# Altium schematic sheet Light Sensor Prototype.SchDoc("Light Sensor Prototype")
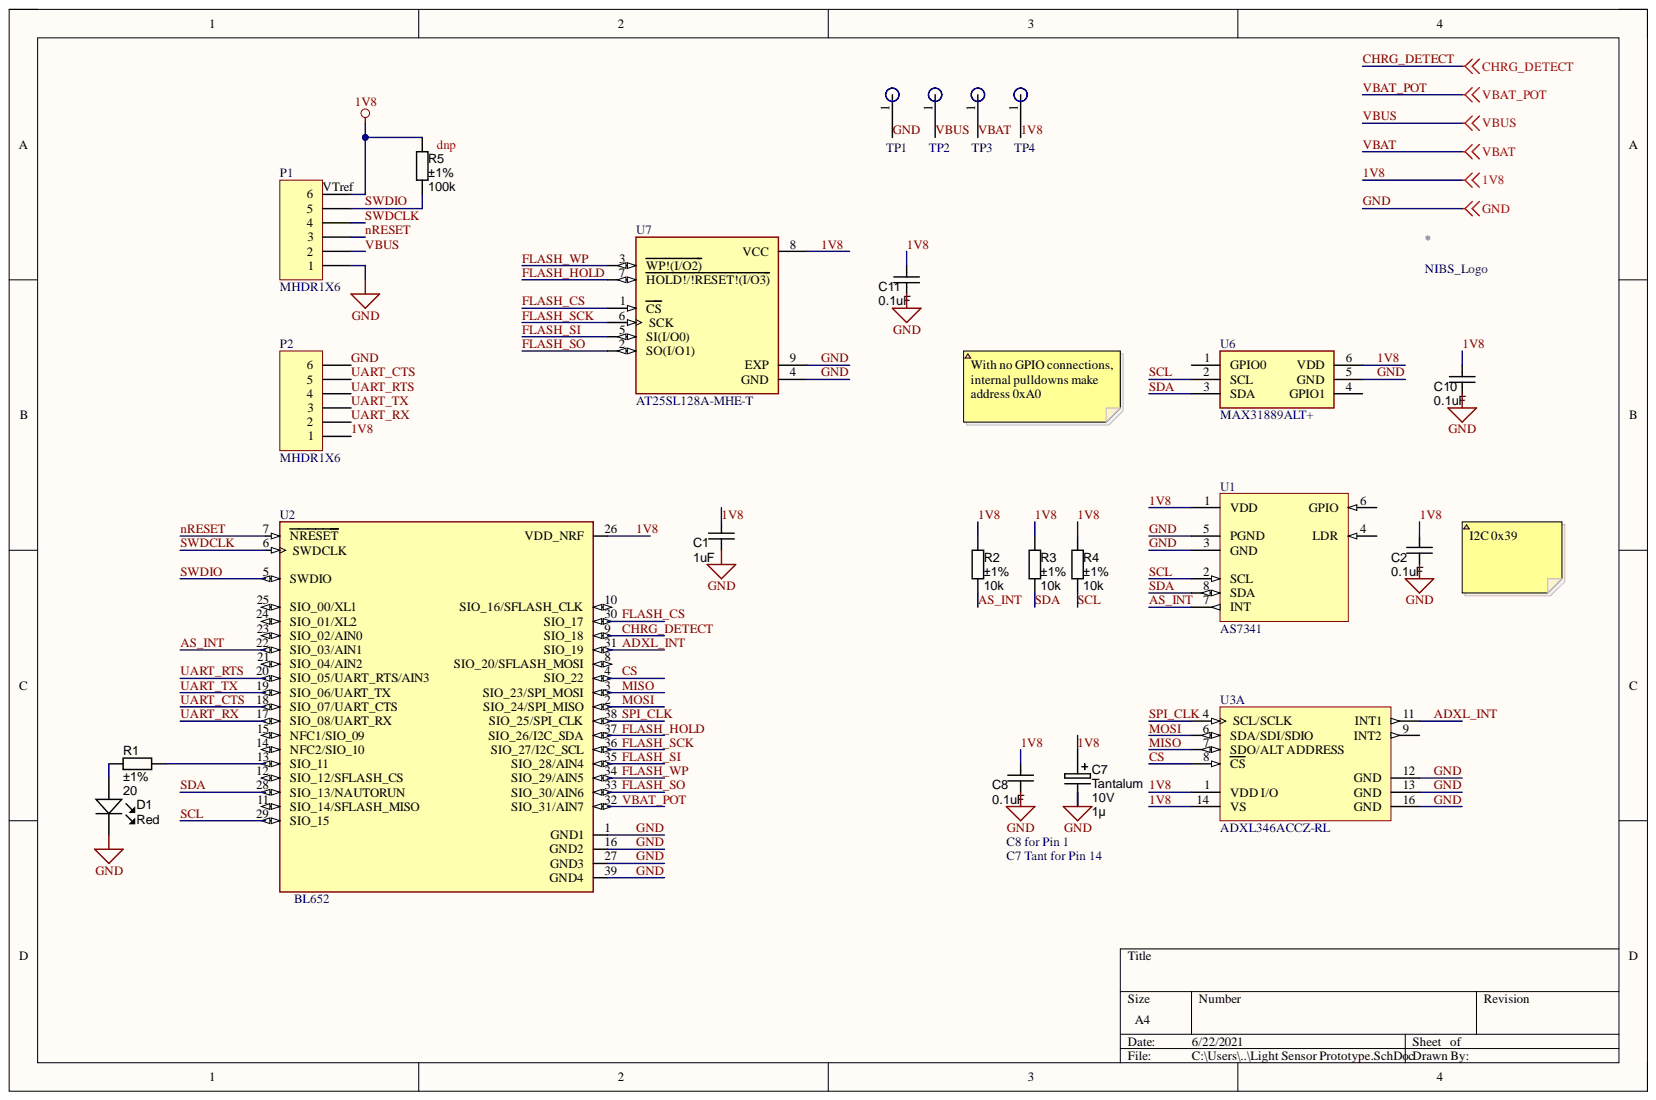
[diagram p0: Light Sensor Prototype.SchDoc]

## Parts
*  NIBS_Logo  [Logo]
C1  value 1uF  [CAPC1608X90N]  (hidden comment: approved sub OK)
C2  value 0.1uF  [CAPC1608X90N]  (hidden comment: approved sub OK)
C7  1µ  Material: Tantalum  Ratings: 10V  [CAPMP2012X150USR]
C8  value 0.1uF  [CAPC1608X90N]  (hidden comment: approved sub OK)
C10  value 0.1uF  [CAPC1608X90N]  (hidden comment: approved sub OK)
C11  value 0.1uF  [CAPC1608X90N]  (hidden comment: approved sub OK)
D1  Red  [LED-0603-RED]
P1  MHDR1X6  [MHDR1X6]
P2  MHDR1X6  [MHDR1X6]
R1  value 20  Tolerance: ±1%  [RESC1608X55N]  (hidden comment: Thick Film)
R2  value 10k  Tolerance: ±1%  [RESC1608X55N]  (hidden comment: Thick Film)
R3  value 10k  Tolerance: ±1%  [RESC1608X55N]  (hidden comment: Thick Film)
R4  value 10k  Tolerance: ±1%  [RESC1608X55N]  (hidden comment: Thick Film)
R5  value 100k  Tolerance: ±1%  [RESC1608X55N]
TP1  Test Point  [TP]  (value not drawn)
TP2  Test Point  [TP]  (value not drawn)
TP3  Test Point  [TP]  (value not drawn)
TP4  Test Point  [TP]  (value not drawn)
U1  AS7341  [OLGA8]
U2  BL652  [BL652]
U3  ADXL346ACCZ-RL  [ADI-CC-16-3_N]  (part A)
U6  MAX31889ALT+  [MAX31889ALT]
U7  AT25SL128A-MHE-T  [SON127P500X600X60-9N]

## Nets
1V8: C1.1 C2.1 C7.1 C8.1 C10.1 C11.1 P1.6 P2.1 R2.1 R3.1 R4.1 R5.2 TP4.1 U1.1(VDD) U2.26(VDD_NRF) U3.1(VDD_I/O) U3.14(VS) U6.6(VDD) U7.8(VCC)
ADXL_INT: U2.31(SIO_19) U3.11(INT1)
AS_INT: R2.2 U1.7(INT) U2.22(SIO_03/AIN1)
CHRG_DETECT: U2.9(SIO_18)
CS: U2.4(SIO_22) U3.8(CS)
FLASH_CS: U2.30(SIO_17) U7.1(CS)
FLASH_HOLD: U2.37(SIO_26/I2C_SDA) U7.7(HOLD!/!RESET!(I/O3))
FLASH_SCK: U2.36(SIO_27/I2C_SCL) U7.6(SCK)
FLASH_SI: U2.35(SIO_28/AIN4) U7.5(SI(I/O0))
FLASH_SO: U2.33(SIO_30/AIN6) U7.2(SO(I/O1))
FLASH_WP: U2.34(SIO_29/AIN5) U7.3(WP!(I/O2))
GND: C1.2 C2.2 C7.2 C8.2 C10.2 C11.2 D1.K P1.1 P2.6 TP1.1 U1.3(GND) U1.5(PGND) U2.1(GND1) U2.16(GND2) U2.27(GND3) U2.39(GND4) U3.12(GND) U3.13(GND) U3.16(GND) U6.5(GND) U7.4(GND) U7.9(EXP)
MISO: U2.3(SIO_23/SPI_MOSI) U3.7(SDO/ALT_ADDRESS)
MOSI: U2.2(SIO_24/SPI_MISO) U3.6(SDA/SDI/SDIO)
nRESET: P1.3 U2.7(NRESET)
SCL: R4.2 U1.2(SCL) U2.29(SIO_15) U6.2(SCL)
SDA: R3.2 U1.8(SDA) U2.28(SIO_13/NAUTORUN) U6.3(SDA)
SPI_CLK: U2.38(SIO_25/SPI_CLK) U3.4(SCL/SCLK)
SWDCLK: P1.4 U2.6(SWDCLK)
SWDIO: P1.5 R5.1 U2.5(SWDIO)
UART_CTS: P2.5 U2.18(SIO_07/UART_CTS)
UART_RTS: P2.4 U2.20(SIO_05/UART_RTS/AIN3)
UART_RX: P2.2 U2.17(SIO_08/UART_RX)
UART_TX: P2.3 U2.19(SIO_06/UART_TX)
VBAT: TP3.1
VBAT_POT: U2.32(SIO_31/AIN7)
VBUS: P1.2 TP2.1
NetD1_A: D1.A R1.1
NetR1_2: R1.2 U2.13(SIO_11)

Not connected: U1 4(LDR) 6(GPIO); U2 8(SIO_20/SFLASH_MOSI) 10(SIO_16/SFLASH_CLK) 11(SIO_14/SFLASH_MISO) 12(SIO_12/SFLASH_CS) 14(NFC2/SIO_10) 15(NFC1/SIO_09) 21(SIO_04/AIN2) 23(SIO_02/AIN0) 24(SIO_01/XL2) 25(SIO_00/XL1); U3 9(INT2); U6 1(GPIO0) 4(GPIO1)

## Library data of the parts
C1 C2 C8 C10 C11 = CAPC_100n_0603_X7R_50V_10%: Capacitor SMD Cer 10uF 10% 16V X5R 0603; Manufacturer: TDK; Manufacturer Part Number: C1608X5R1C106M080AB; Material: ceramic; Ratings: 16V; X5R; Component Kind: Standard; Package / Case: 0603 (1608 Metric); Supplier: Farnell; Supplier Link: https://uk.farnell.com/tdk/c1608x5r1c106m080ab/cap-10-f-16v-20-x5r-0603/dp/2525096?st=2521959; Supplier Part Number: 2525096; Tolerance: ±10%; Library Name: UEKAE.SCHLIB
C7 = CAPT_1u_0805_10V: Capacitor SMD Tantalum 1uF 20% 10V 0805; Manufacturer 1: AVX Corporation; Manufacturer Part Number 1: TAJP106M010RNJ; Manufacturer Size Code: P; ESR (Equivalent Series Resistance): 6.000 Ohm; SCDType: spec; Type: Molded; Component Kind: Standard; ComponentLink1Description: http://www.avx.com/docs/Catalogs/tajlp.pdf; ComponentLink1URL: http://www.avx.com/docs/Catalogs/tajlp.pdf; Height - Seated (Max): 0.059" (1.50mm); Operating Temperature: -55°C ~ 125°C; Package / Case: 0805 (2012 metric); Size / Dimension: 0.081" L x 0.053" W (2.05mm x 1.35mm); Supplier 1: Digi-Key; Supplier Part Number 1: 478-3281-1-ND; Tolerance: ±20%; Value: 1µ; Library Name: Libs_RRutledge.IntLib
D1 = LED_SMD_Red_0603_220mcd: Dialight 598-8E40-107F; Manufacturer 1: Kingbright Company LLC; Manufacturer Part Number 1: APT1608SURCK; Material: AlGaInP on GaAs; Ratings: 220 mcd; 630 nm; SCDType: source; Voltage - Forward (Vf) @ 10mA: 1.9V; Voltage - Forward (Vf) Typ: 1.95V; Component Kind: Standard; ComponentLink1Description: http://www.us.kingbright.com/images/catalog/SPEC/APT1608SURCK.pdf; ComponentLink1URL: http://www.us.kingbright.com/images/catalog/SPEC/APT1608SURCK.pdf; Package / Case: 0603 (1608 metric); Supplier 1: Digi-Key; Supplier Part Number 1: 754-1123-1-ND; Library Name: Libs_RRutledge.IntLib
P1 P2 = MHDR1X6: Header, 6-Pin; Component Kind: Standard; LatestRevisionDate: 17-Jul-2002; LatestRevisionNote: Re-released for DXP Platform.; Library Name: Miscellaneous Connectors.IntLib; Signal Integrity: Connector; Publisher: Altium Limited
R1 = RES_10K_0603_1%: Resistor SMD chip 10k Ohm 0.1W 1% 0603; Manufacturer 1: Yageo; Manufacturer Part Number 1: RC0603FR-0710KL; Ratings: 1/10W; SCDType: spec; Value: 20; Component Kind: Standard; Package / Case: 0603 (1608 Metric); Supplier 1: Digi-Key; Supplier Part Number 1: 311-10.0KHRCT-ND; Library Name: Libs_RRutledge.IntLib
R2-R5 = RES_10K_0603_1%: Resistor SMD chip 10k Ohm 0.1W 1% 0603; Manufacturer 1: Yageo; Manufacturer Part Number 1: RC0603FR-0710KL; Ratings: 1/10W; SCDType: spec; Component Kind: Standard; Package / Case: 0603 (1608 Metric); Supplier 1: Digi-Key; Supplier Part Number 1: 311-10.0KHRCT-ND; Library Name: Libs_RRutledge.IntLib
U1 = AS7341: AMS AS7341  11-Channel Spectral Sensor Frontend; Component Kind: Standard; Library Name: Light Sensor Prototype.SchLib
U3 = ADXL346ACCZ-RL: 3-Axis, +/-2 g / +/-4 g / +/-8 g / +/-16 g Ultralow Power Digital Accelerometer with Digital Output, 1.6 KHz BW, Industrial, 16-pin LGA, Reel; Manufacturer: Analog Devices; # of Axes: 3; -3dB Bandwidth: 1.6 KHz; Sensitivity: 256/128/64/32 LSB/g; Supply Current: 140 uA; Voltage Supply (V): 1.7 to 2.75; Component Kind: Standard; ComponentLink1Description: Manufacturer URL; ComponentLink1URL: http://www.analog.com/en/index.html; ComponentLink2Description: Datasheet; ComponentLink2URL: http://www.analog.com/static/imported-files/data_sheets/ADXL346.pdf; ComponentLink3Description: Package Specification; ComponentLink3URL: http:/www.analog.com/static/imported-files/packages/PKG_PDF/LGA(CC)/CC_16_3.pdf; ComponentLink4Description: Product URL; ComponentLink4URL: http://www.analog.com/en/mems-sensors/mems-inertial-sensors/adxl346/products/product.html; DatasheetVersion: Rev. B; Mounting Technology: Surface Mount; Output Type: Digital; PackageDescription: 16-Pin Land Grid Array, 3 x 3 mm Body, 0.5 mm Pitch; PackageReference: CC-16-3; PackageVersion: 07-30-2012-B, 07/2012; Packing: Reel; PartNumber: ADXL346ACCZ-RL; Supplier 1: Digi-Key; Supplier 2: Newark; Supplier 3: Mouser; Supplier Part Number 1: ADXL346ACCZ-RL-ND; Supplier Part Number 2: 74R5959; Supplier Part Number 3: 584-ADXL346ACCZ-R; Library Name: Analog Devices MEMS Inertial Sensors.IntLib
U6 = MAX31889ALT+: Integrated Circuit; Manufacturer_Name: Maxim Integrated; Component Kind: Standard; Datasheet Link: https://pdfserv.maximintegrated.com/en/ds/MAX31889.pdf; Height: 0.8mm; Manufacturer_Part_Number: MAX31889ALT+
U7 = AT25SL128A-MHE-T: FLASH Memory IC 128Mb (16M x 8) SPI 104MHz 8-UDFN (5x6); MF: Adesto Technologies; Availability: Warning; Check_prices: https://www.snapeda.com/parts/AT25SL128A-MHE-T/Adesto%20Technologies/view-part/981769/?ref=eda; Component Kind: Standard; Library Name: AT25SL128A-MHE-T.IntLib; MP: AT25SL128A-MHE-T; Package: UDFN-8 Adesto Technologies; Price: None; Purchase-URL: https://pricing.snapeda.com/search/part/AT25SL128A-MHE-T/?ref=eda; SnapEDA_Link: https://www.snapeda.com/parts/AT25SL128A-MHE-T/Adesto%20Technologies/view-part/981769/?ref=snap
* = NIBS_Logo: Component Kind: Standard; Library Name: Light Sensor Prototype.SchLib
TP1-TP4 = Test Point: Component Kind: Standard; Library Name: Light Sensor Prototype.SchLib
U2 = BL652: Component Kind: Standard; Library Name: Light Sensor Prototype.SchLib
